# Altium schematic sheet PwrFPGA.SchDoc(PwrFPGA)
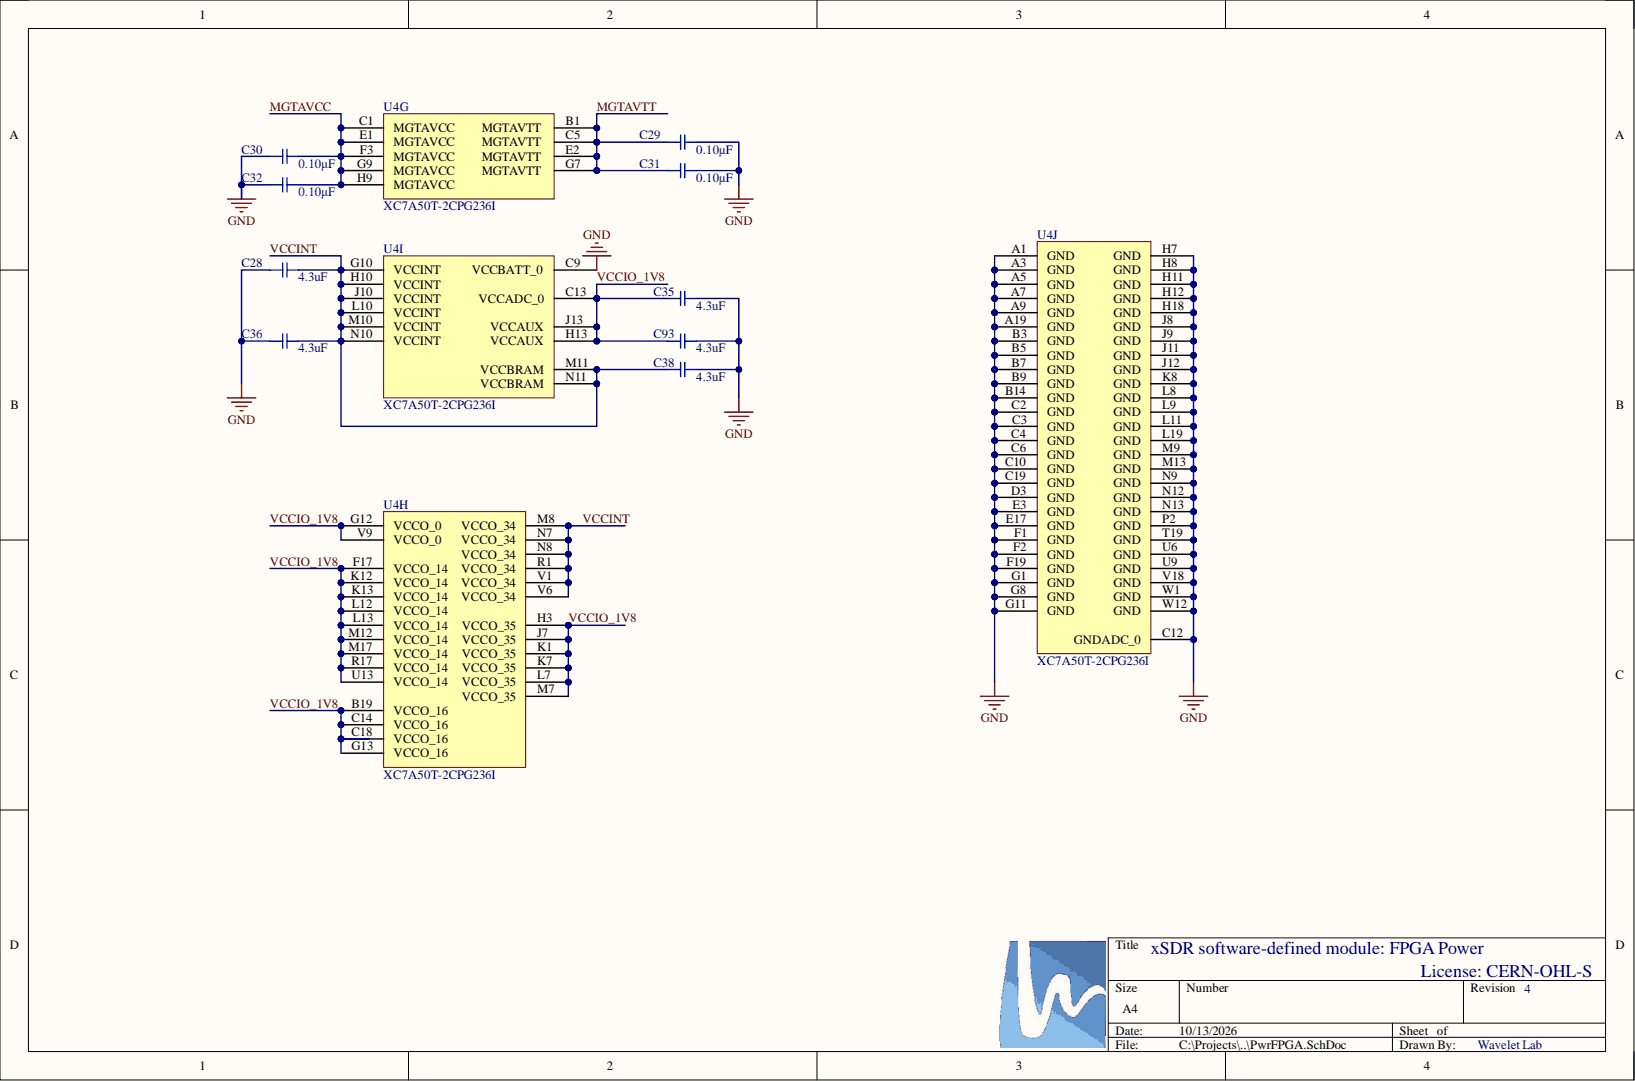
[diagram p0: PwrFPGA.SchDoc]

## Parts
C28  4.3uF  [SMD-02404-CAP]
C29  value 0.10µF  [SMD-0201C]
C30  value 0.10µF  [SMD-0201C]
C31  value 0.10µF  [SMD-0201C]
C32  value 0.10µF  [SMD-0201C]
C35  4.3uF  [SMD-02404-CAP]
C36  4.3uF  [SMD-02404-CAP]
C38  4.3uF  [SMD-02404-CAP]
C93  4.3uF  [SMD-02404-CAP]
U4  PartNumber: XC7A50T-2CPG236I  [CPG236]  (parts G,H,I,J)

## Nets
GND: C28.1 C29.2 C30.1 C31.2 C32.1 C35.2 C36.1 C38.2 C93.2 U4.A1(GND) U4.A3(GND) U4.A5(GND) U4.A7(GND) U4.A9(GND) U4.A19(GND) U4.B3(GND) U4.B5(GND) U4.B7(GND) U4.B9(GND) U4.B14(GND) U4.C2(GND) U4.C3(GND) U4.C4(GND) U4.C6(GND) U4.C9(VCCBATT_0) U4.C10(GND) U4.C12(GNDADC_0) U4.C19(GND) U4.D3(GND) U4.E3(GND) U4.E17(GND) U4.F1(GND) U4.F2(GND) U4.F19(GND) U4.G1(GND) U4.G8(GND) U4.G11(GND) U4.H7(GND) U4.H8(GND) U4.H11(GND) U4.H12(GND) U4.H18(GND) U4.J8(GND) U4.J9(GND) U4.J11(GND) U4.J12(GND) U4.K8(GND) U4.L8(GND) U4.L9(GND) U4.L11(GND) U4.L19(GND) U4.M9(GND) U4.M13(GND) U4.N9(GND) U4.N12(GND) U4.N13(GND) U4.P2(GND) U4.T19(GND) U4.U6(GND) U4.U9(GND) U4.V18(GND) U4.W1(GND) U4.W12(GND)
MGTAVCC: C30.2 C32.2 U4.C1(MGTAVCC) U4.E1(MGTAVCC) U4.F3(MGTAVCC) U4.G9(MGTAVCC) U4.H9(MGTAVCC)
MGTAVTT: C29.1 C31.1 U4.B1(MGTAVTT) U4.C5(MGTAVTT) U4.E2(MGTAVTT) U4.G7(MGTAVTT)
VCCINT: C28.2 C36.2 C38.1 U4.G10(VCCINT) U4.H10(VCCINT) U4.J10(VCCINT) U4.L10(VCCINT) U4.M8(VCCO_34) U4.M10(VCCINT) U4.M11(VCCBRAM) U4.N7(VCCO_34) U4.N8(VCCO_34) U4.N10(VCCINT) U4.N11(VCCBRAM) U4.R1(VCCO_34) U4.V1(VCCO_34) U4.V6(VCCO_34)
VCCIO_1V8: C35.1 C93.1 U4.B19(VCCO_16) U4.C13(VCCADC_0) U4.C14(VCCO_16) U4.C18(VCCO_16) U4.F17(VCCO_14) U4.G12(VCCO_0) U4.G13(VCCO_16) U4.H3(VCCO_35) U4.H13(VCCAUX) U4.J7(VCCO_35) U4.J13(VCCAUX) U4.K1(VCCO_35) U4.K7(VCCO_35) U4.K12(VCCO_14) U4.K13(VCCO_14) U4.L7(VCCO_35) U4.L12(VCCO_14) U4.L13(VCCO_14) U4.M7(VCCO_35) U4.M12(VCCO_14) U4.M17(VCCO_14) U4.R17(VCCO_14) U4.U13(VCCO_14) U4.V9(VCCO_0)

## Library data of the parts
C28 C35 C36 C38 C93 = LLL1U4R60G435ME22: CAP CER 4.3UF 4V X5R 02404 (0610M); Manufacturer: Murata Electronics North America; Tolerance: ±20%; Component Kind: Standard; Datasheets: https://search.murata.co.jp/Ceramy/image/img/A01X/G101/ENG/LLL1U4R60G435ME22-01.pdf; Digi-Key Part Number: LLL1U4R60G435ME22D-ND; Failure Rate: Surface Mount, MLCC; Mounting Type: 02404 (0610 Metric); Operating Temperature: -55°C ~ 85°C; Package / Case: 0.024" L x 0.039" W (0.60mm x 1.00mm); Part Number: LLL1U4R60G435ME22; Part Status: Active; Series: LLL; Temperature Coefficient: X5R; Value: 4.3uF; Voltage: 4V; Library Name: USDR.IntLib
C29-C32 = GRM033R60J104ME19D: CAP CER 0.1UF 6.3V X5R 0201; Manufacturer: Murata Electronics North America; @ qty: 0; Minimum Quantity: 1; Tolerance: ±20%; Unit Price (USD): 0.1; Applications: General Purpose; Component Kind: Standard; Datasheets: http://www.murata.com/~/media/webrenewal/support/library/catalog/products/capacitor/mlcc/c02e.pdf; Digi-Key Part Number: 490-5404-1-ND; Factory Stock: 0; Failure Rate: Surface Mount, MLCC; Features: -; Height - Seated (Max): 0.013" (0.33mm); Image: //media.digikey.com/Renders/Murata%20Renders/ZRB-SERIES-0402.jpg; Lead Spacing: -; Mounting Type: 0201 (0603 Metric); Operating Temperature: -55°C ~ 85°C; Package / Case: 0.024" L x 0.012" W (0.60mm x 0.30mm); Packaging: Cut Tape (CT); Part Number: GRM033R60J104ME19D; Part Status: Active; Quantity Available: 381529; Ratings: -; Series: GRM; Size / Dimension: -; Temperature Coefficient: X5R; Thickness (Max): -; Voltage: 6.3V; Library Name: USDR.IntLib
U4 = XC7A35T-2CPG236C: Artix-7 FPGA; Manufacturer: Xilinx; Available User I/O: 106; Clock Mgmt Tiles (CMTs): 5; GTP: 2; Logic Cells: 33280; Total Block RAM: 1800 Kb; Component Kind: Standard; ComponentLink1Description: Manufacturer URL; ComponentLink1URL: http://www.xilinx.com/; ComponentLink2Description: Datasheet; ComponentLink2URL: http://www.xilinx.com/support/documentation/data_sheets/ds180_7Series_Overview.pdf; ComponentLink3Description: Package Specification; ComponentLink3URL: http://www.xilinx.com/support/documentation/user_guides/ug475_7Series_Pkg_Pinout.pdf; ComponentLink4Description: DC and Switching Characteristics; ComponentLink4URL: http://www.xilinx.com/support/documentation/data_sheets/ds181_Artix_7_Data_Sheet.pdf; ComponentLink5Description: Package File; ComponentLink5URL: http://www.xilinx.com/support/packagefiles/a7packages/xc7a200tsbg484pkg.txt; ComponentLink6Description: Packaging and Pinout; ComponentLink6URL: http://www.xilinx.com/support/documentation/user_guides/ug475_7Series_Pkg_Pinout.pdf; DatasheetVersion: v1.15; Maximum Distributed RAM: 400 Kb; Mounting Technology: Surface Mount; NexusDeviceID: XC7A35T-2CPG236C; Operating Temperature Range: Commercial; PackageDescription: 238-Ball Wire-Bond Chip-Scale BGA, Body 10 x10 mm, Pitch 0.5 mm; PackageReference: CPG-236; PackageVersion: Rev. 1.11; Speed Grades: 2; Library Name: USDR.IntLib
